# Altium schematic sheet DTU_RS485_CAN.SchDoc
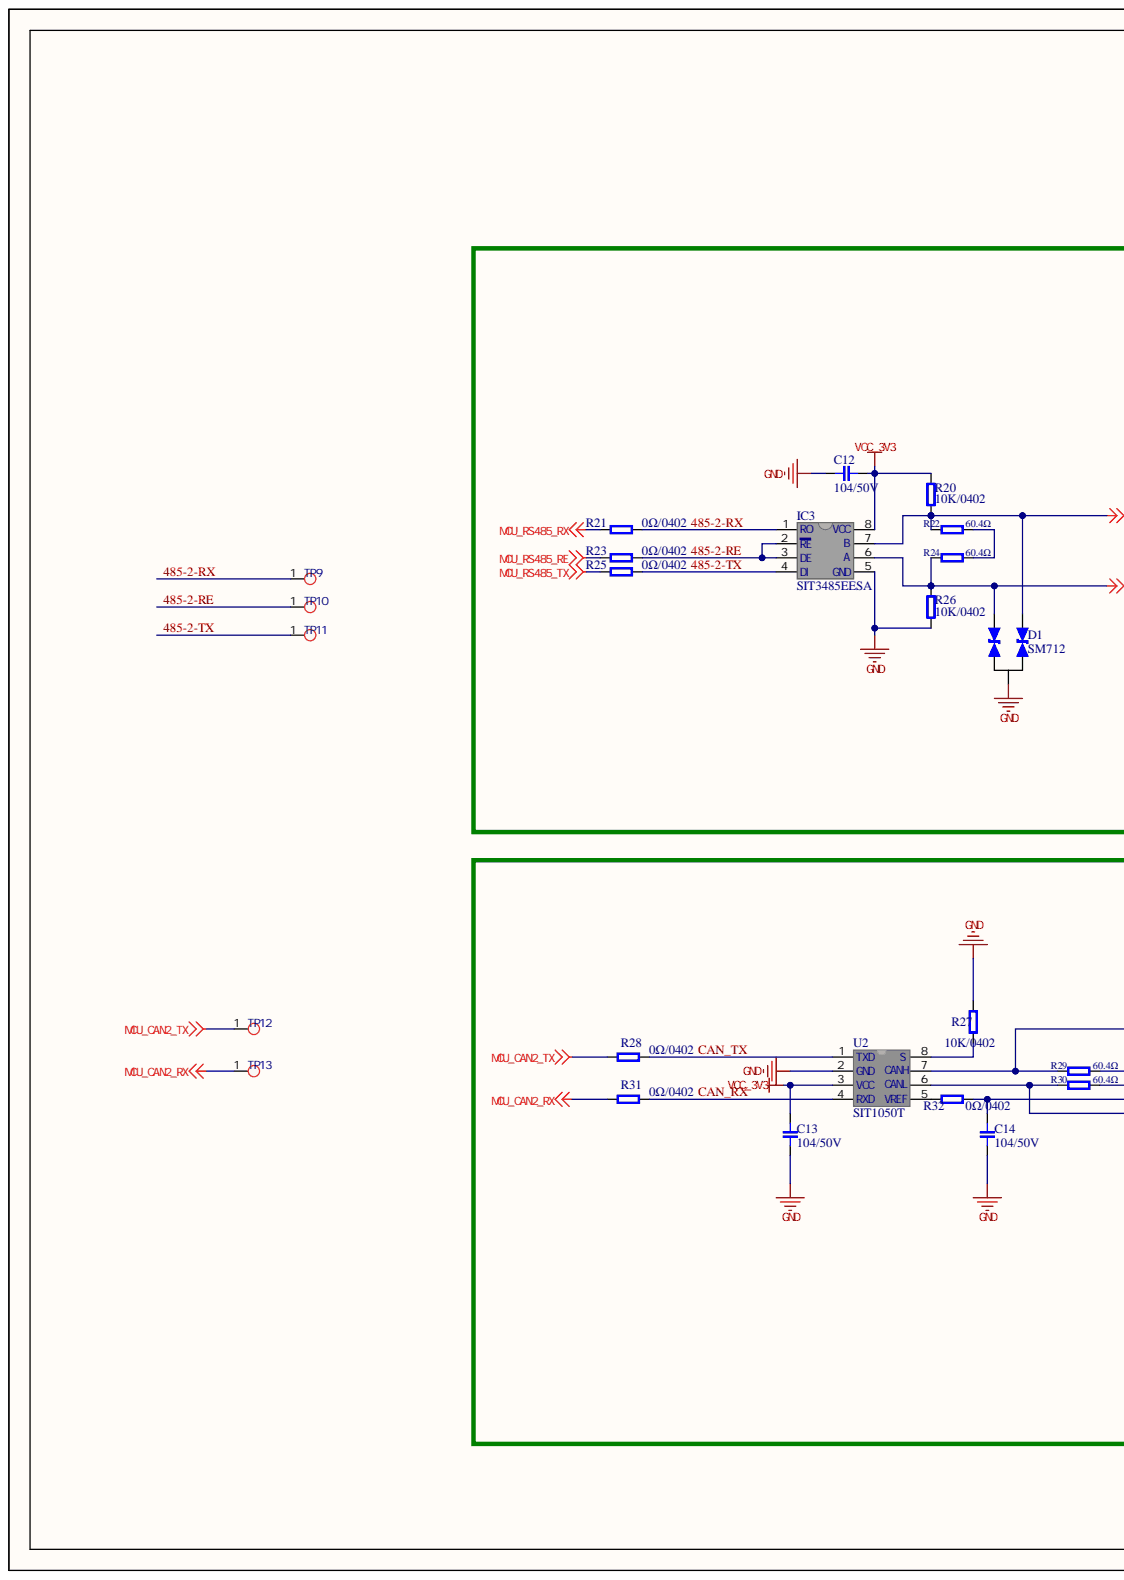
[diagram p0: DTU_RS485_CAN.SchDoc, left]
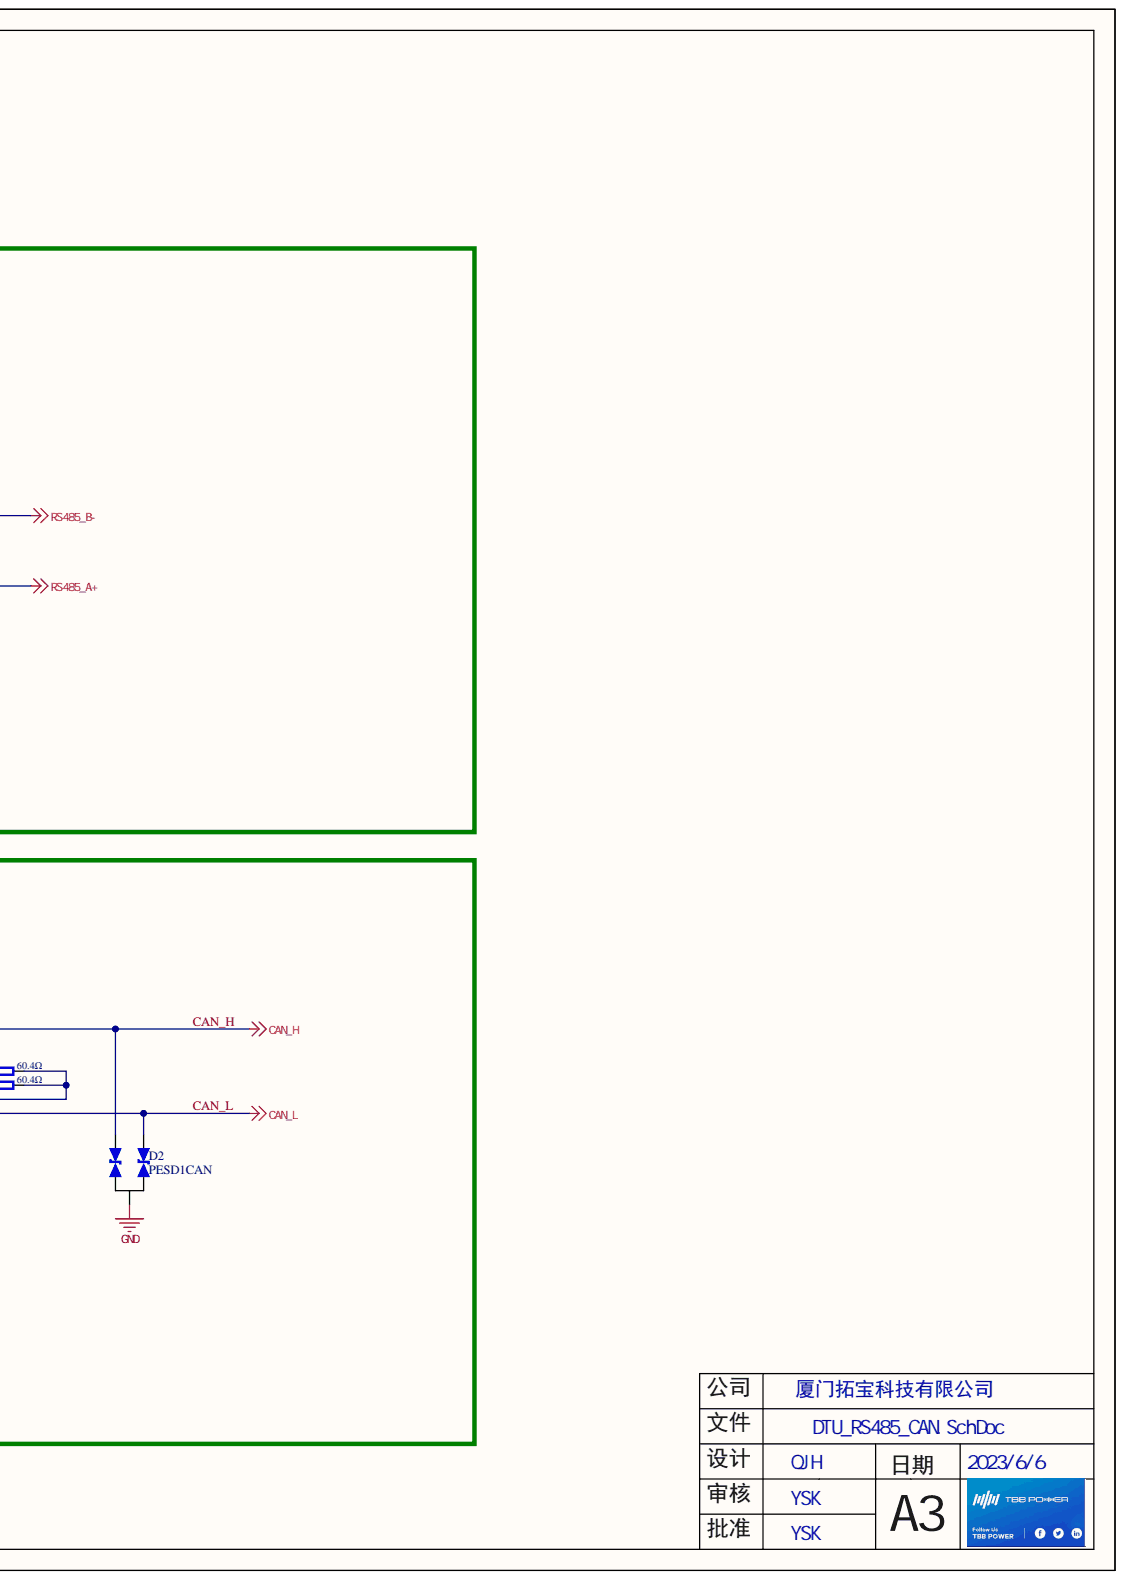
[diagram p1: DTU_RS485_CAN.SchDoc, right]

## Parts
C12  104/50V  [C0402]
C13  104/50V  [C0402]
C14  104/50V  [C0402]
D1  SM712  [SOT-23]
D2  PESD1CAN  [SOT-23]
IC3  SIT3485EESA  [SO-8]
R20  10K/0402  [R0402]
R21  0Ω/0402  [R0402]
R22  60.4Ω  [R0603]
R23  0Ω/0402  [R0402]
R24  60.4Ω  [R0603]
R25  0Ω/0402  [R0402]
R26  10K/0402  [R0402]
R27  10K/0402  [R0402]
R28  0Ω/0402  [R0402]
R29  60.4Ω  [R0603]
R30  60.4Ω  [R0603]
R31  0Ω/0402  [R0402]
R32  0Ω/0402  [R0402]
TP9  TP  [TP-SMD]  (value not drawn)  (no BOM)
TP10  TP  [TP-SMD]  (value not drawn)  (no BOM)
TP11  TP  [TP-SMD]  (value not drawn)  (no BOM)
TP12  TP  [TP-SMD]  (value not drawn)  (no BOM)
TP13  TP  [TP-SMD]  (value not drawn)  (no BOM)
U2  SIT1050T  [SO-8]

## Nets
GND: C12.1 C13.2 C14.2 D1.3 D2.3 IC3.5(GND) R26.1 R27.2 U2.2(G)
MCU_CAN2_RX: R31.1 TP13.1
MCU_CAN2_TX: R28.1 TP12.1
MCU_RS485_RE: R23.1
MCU_RS485_RX: R21.1
MCU_RS485_TX: R25.1
RS485_A+: D1.2 IC3.6(A) R24.1 R26.2
RS485_B-: D1.1 IC3.7(B) R20.1 R22.1
VCC_3V3: C12.2 C13.1 IC3.8(VCC) R20.2 U2.3(V)
485-2-RE: IC3.2(RE) IC3.3(DE) R23.2 TP10.1
485-2-RX: IC3.1(RO) R21.2 TP9.1
485-2-TX: IC3.4(DI) R25.2 TP11.1
CAN_H: D2.2 R29.2 U2.7(CANH)
CAN_L: D2.1 R30.2 U2.6(CANL)
CAN_RX: R31.2 U2.4(RX)
CAN_TX: R28.2 U2.1(TX)
NetC14_1: C14.1 R29.1 R30.1 R32.2
NetR22_2: R22.2 R24.2
NetR27_1: R27.1 U2.8(SS)
NetR32_1: R32.1 U2.5(EF)

## Library data of the parts
C12-C14 = C0402_100nF_50V: 贴片电容,X7R,0402,104,100nF,50V,±10%,-55℃～125℃; Part_number: 10206-0123; Component Kind: Standard; Library Name: Capacity.SchLib
D1 = SM712: SM712 SOT23-3 TVS Diode  Vrwm 7V+12V protect RS485; 料号: 10501-0; Component Kind: Standard; Library Name: EMS-主板（PCB-211113-01）V01.SCHLIB
D2 = PESD1CAN: PESD1CAN SOT23-3 TVS Diode  Vrwm 24V protect CAN; 料号: 10501-0; Component Kind: Standard; Library Name: EMS.SCHLIB
IC3 = SIT3485EESA: 贴片IC,SIT3485EESA,485通讯芯片,兼容5V和3.3V,SOP8,-40℃~125℃; Part_number: 10802-0210; Component Kind: Standard; Library Name: Q_IC.SchLib
R20 R26 R27 = R0402_10K: 贴片电阻,RTT02103JTH,0402,10K,5%,Ralec; 料号: 10102-0279; Component Kind: Standard; Library Name: Resistance.SchLib
R21 R23 R25 R28 R31 R32 = R0402_0Ω: 贴片电阻,0402,0Ω,1/16W,±1%; Part_number: 10102-0253; Component Kind: Standard; Library Name: Resistance.SchLib
R22 R24 R29 R30 = R0603_60.4Ω: 贴片电阻,0603,60.4Ω,1/10W,±1%; Part_number: 10102-0072; Component Kind: Standard; Library Name: Resistance.SchLib
TP9-TP13 = TP: PCB测试端子; Library Name: CONNECTOR.IntLib
U2 = TJA1050T: 贴片IC,SIT1050T,CAN收发器,SOP8,-40℃~125℃,芯力特,编带; Part_number: 10802-0211; Component Kind: Standard; Library Name: IC.IntLib
